# Altium schematic sheet misc.SchDoc(misc)
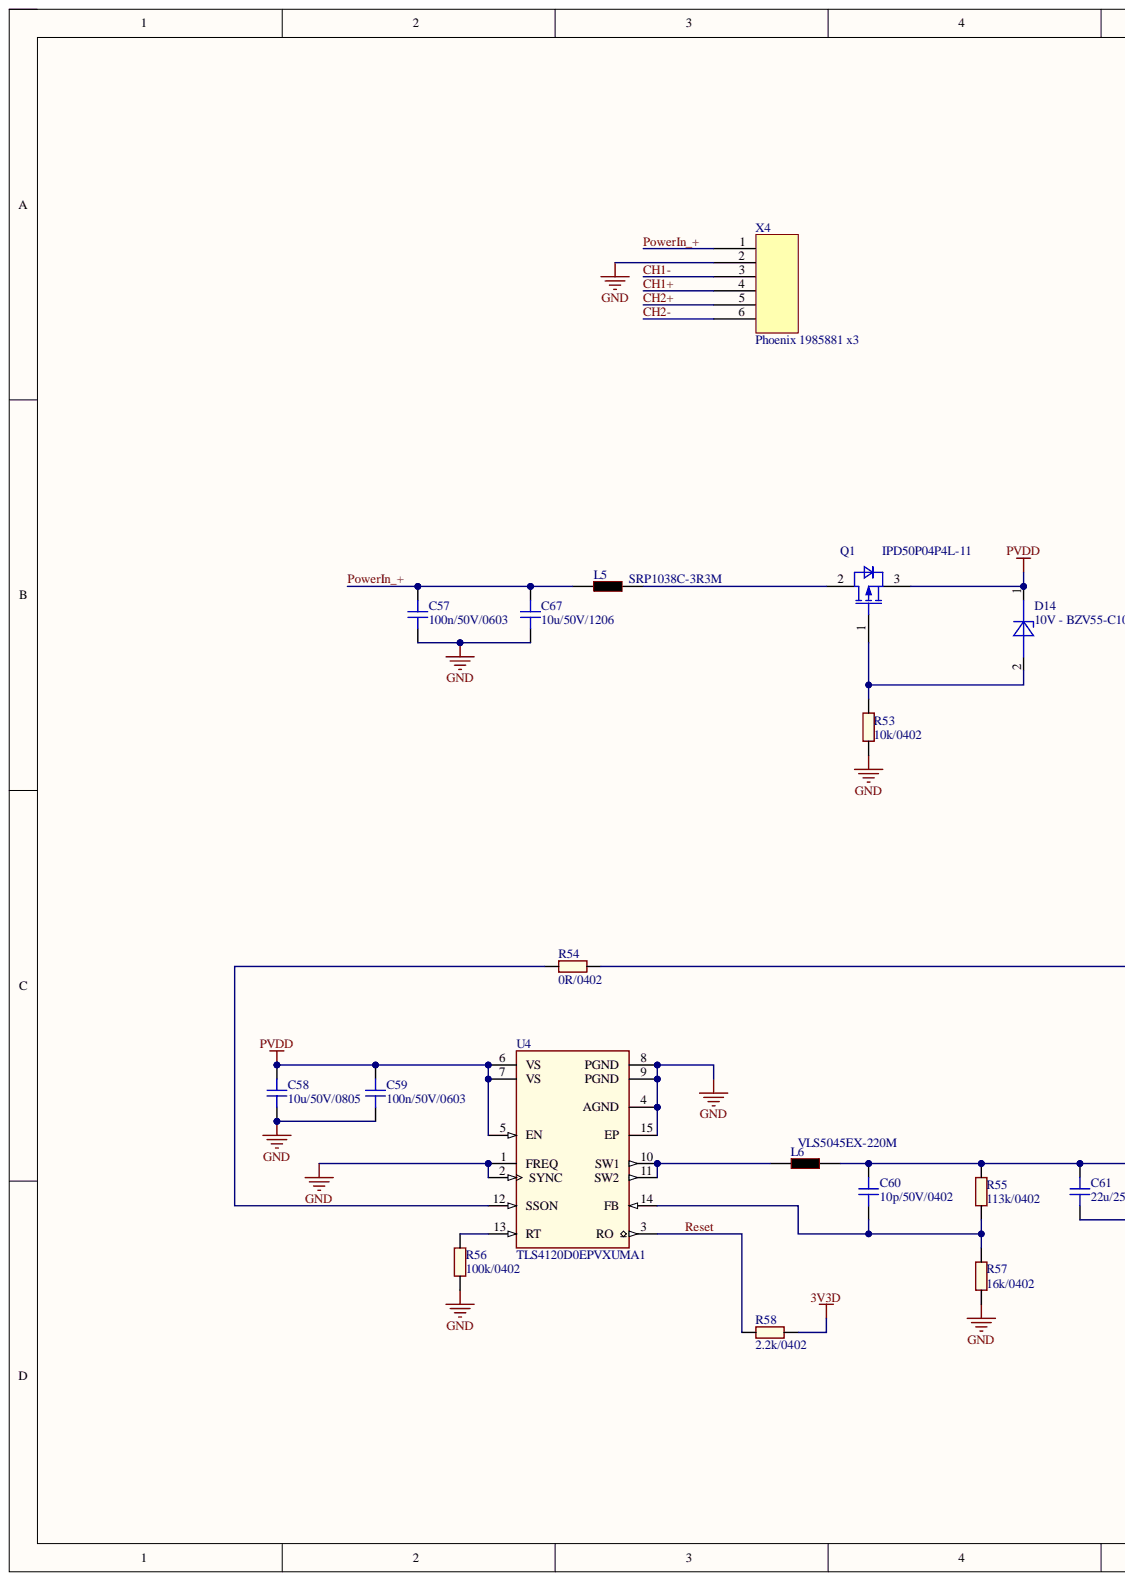
[diagram p0: misc.SchDoc, left]
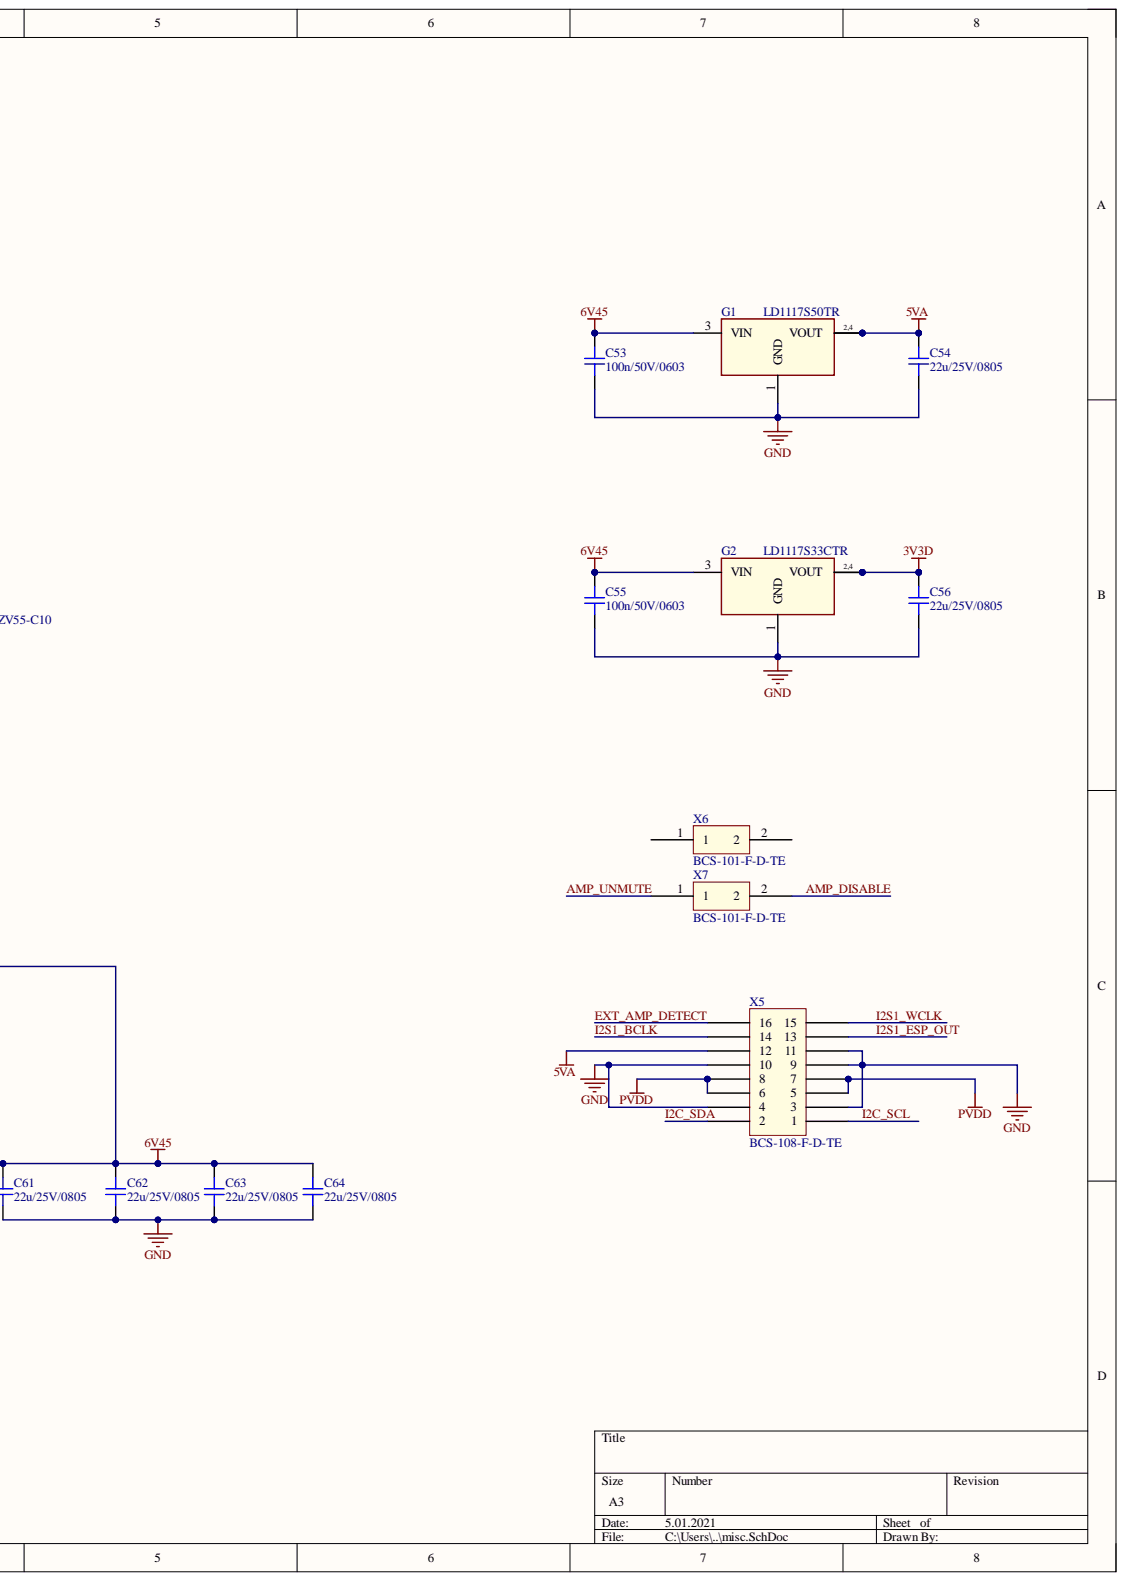
[diagram p1: misc.SchDoc, right]

## Parts
C53  100n/50V/0603  [CAPC1608X87N]
C54  22u/25V/0805  [CAPC2013X140N]
C55  100n/50V/0603  [CAPC1608X87N]
C56  22u/25V/0805  [CAPC2013X140N]
C57  100n/50V/0603  [CAPC1608X87N]
C58  10u/50V/0805  [CAPC2013X140N]
C59  100n/50V/0603  [CAPC1608X87N]
C60  10p/50V/0402  [CAPC1005X55N-0]
C61  22u/25V/0805  [CAPC2013X140N]
C62  22u/25V/0805  [CAPC2013X140N]
C63  22u/25V/0805  [CAPC2013X140N]
C64  22u/25V/0805  [CAPC2013X140N]
C67  10u/50V/1206  [CAPC3216X70N]
D14  10V - BZV55-C10  value 10V  [DIOMELF3515N-2]
G1  LD1117S50TR  [SOT230P700X180-4N]
G2  LD1117S33CTR  [SOT230P700X180-4N]
L5  SRP1038C-3R3M  [SRP1038C]
L6  VLS5045EX-220M  [VLS5045EX]
Q1  IPD50P04P4L-11  [TO228P998X250-3N-V]
R53  10k/0402  [RESC1005X40N]
R54  0R/0402  [RESC1005X40N]
R55  113k/0402  [RESC1005X40N]
R56  100k/0402  [RESC1005X40N]
R57  16k/0402  [RESC1005X40N]
R58  2.2k/0402  [RESC1005X40N]
U4  TLS4120D0EPVXUMA1  [SOP65P600X115-15N]
X4  Phoenix 1985881 x3  [Phoenix 1985881 x3]
X5  BCS-108-F-D-TE  [BCS-108-F-D-TE]
X6  BCS-101-F-D-TE  [BCS-101-F-D-TE]
X7  BCS-101-F-D-TE  [BCS-101-F-D-TE]

## Nets
3V3D: C56.2 G2.2(VOUT) G2.4 R58.1
5VA: C54.2 G1.2(VOUT) G1.4 X5.12
6V45: C53.1 C55.1 C60.2 C61.2 C62.2 C63.2 C64.2 G1.3(VIN) G2.3(VIN) L6.2 R54.1 R55.2
GND: C53.2 C54.1 C55.2 C56.1 C57.2 C58.1 C59.2 C61.1 C62.1 C63.1 C64.1 C67.1 G1.1(GND) G2.1(GND) R53.1 R56.1 R57.1 U4.1(FREQ) U4.2(SYNC) U4.4(AGND) U4.8(PGND) U4.9(PGND) U4.15(EP) X4.2 X5.3 X5.4 X5.9 X5.10 X5.11
PVDD: C58.2 C59.1 D14.1 Q1.3 U4.5(EN) U4.6(VS) U4.7(VS) X5.5 X5.6 X5.7 X5.8
AMP_DISABLE: X7.2
AMP_UNMUTE: X7.1
CH1+: X4.4
CH1-: X4.3
CH2+: X4.5
CH2-: X4.6
EXT_AMP_DETECT: X5.16
I2C_SCL: X5.1
I2C_SDA: X5.2
I2S1_BCLK: X5.14
I2S1_ESP_OUT: X5.13
I2S1_WCLK: X5.15
PowerIn_+: C57.1 C67.2 L5.1 X4.1
Reset: R58.2 U4.3(RO)
NetC60_1: C60.1 R55.1 R57.2 U4.14(FB)
NetD14_2: D14.2 Q1.1 R53.2
NetL5_2: L5.2 Q1.2
NetL6_1: L6.1 U4.10(SW1) U4.11(SW2)
NetR54_2: R54.2 U4.12(SSON)
NetR56_2: R56.2 U4.13(RT)

Not connected: X6 1 2

## Library data of the parts
C53 C55 C57 C59 = 251321: CAP / CERA / 100nF / 10V / 5% / X7R (EIA) / -55°C to 125°C / 603(1608) / SMD / -; Manufacturer: Kemet; Manufacturer Order Number: C0603C104J8RAC; Alternate View: Yes; Automotive Qualified: No; Combined Parameters: TC:X7R (EIA);Tol.:-5%/+5%;Vdc:10V; ComponentStatus: Released; Database Table Name: TPartsAll; Legacy DeviceID: CAP00004163; Package Imperial: 603; Package Metric: 1608; PLMStatus: Not Recommended For New Designs; QuickPick: 100nF / 10V / 5% / X7R (EIA) / 0603; Style: Single; Symbol Type: Capacitor; Single; Standard; 2 Pins; Type: Standard; Version Number: V01; Article Number: 251321; Component Kind: Standard; ComponentLink1Description: Vendor WebSite; ComponentLink1URL: http://www.kemet.com; ComponentLink2Description: Datasheet; ComponentLink2URL: http://webnetprod.muc.infineon.com/PCBPortal_Prod/WorkFlows/AltiumComponent/StandardDocumentation?Versionid=251322; Legacy PartNumber: CAP00004163; Library Name: PCBLibraryData.SVNDbLib; Multiple Parts: No; Package Description: 0603; PackageReference: 0603; Part Type: Capacitor; ROHS Compliant: Y; Second Source allowed: Yes; Supplier 1: Farnell; Supplier 2: DigiKey; Supplier 3: Mouser; Supplier Part Number 2: C0603C104J8RACTU-ND; Technology: Ceramic; Temperature max: 125; Temperature min: -55; Temperature Range: Military; Tolerance Neg: 5%; Tolerance Pos: 5%; Value: 100nF; Voltage DC (max): 10V
C54 C56 C58 C61-C64 = 304206: CAP / CERA / 330nF / 25V / 5% / X8R (EIA) / -55°C to 150°C / 0805(2012) / SMD / -; Manufacturer: MuRata; Manufacturer Order Number: GCM21BR91E334JA37; Multiple Parts: No; Alternate View: Yes; Automotive Qualified: AEC Q200; Combined Parameters: TC:X8R (EIA);Tol.:-5%/+5%;Vdc:25V; ComponentStatus: Released; Database Table Name: TPartsAll; Legacy DeviceID: CAP01009910; Package Imperial: 0805; Package Metric: 2012; PLMStatus: Active; QuickPick: 330nF / 25V / 5% / X8R (EIA) / CAPC2013X140N; Style: Single; Symbol Type: Capacitor; Single; Standard; 2 Pins; Type: Standard; Version Number: V01; Application: Standard; Article Number: 304206; Component Kind: Standard; ComponentLink1Description: Vendor WebSite; ComponentLink1URL: http://www.murata.com/; ComponentLink2Description: Datasheet; ComponentLink2URL: http://webnetprod.muc.infineon.com/PCBPortal_Prod/WorkFlows/AltiumComponent/StandardDocumentation?Versionid=304207; Legacy PartNumber: CAP01009910; Library Name: PCBLibraryData.SVNDbLib; Package Description: Capacitor,non-polarized,Chip;2.00mm L X 1.25mm W X 1.40mm H; PackageReference: CAPC2013X140N; Part Type: Capacitor; ROHS Compliant: Y; Second Source allowed: Yes; Supplier 1: Farnell; Supplier 2: DigiKey; Supplier 3: Mouser; Technology: Ceramic; Temperature max: 150; Temperature min: -55; Temperature Range: Military; Tolerance Neg: 5%; Tolerance Pos: 5%; Value: 330nF; Voltage DC (max): 25V
C60 = 263539: CAP / CERA / 10nF / 6.3V / 10% / X5R (EIA) / -55°C to 85°C / 0402(1005) / SMD / -; Manufacturer: MuRata; Manufacturer Order Number: GRM155R60J103KA01; Alternate View: Yes; Automotive Qualified: No; Combined Parameters: TC:X5R (EIA);Tol.:-10%/+10%;Vdc:6.3V; ComponentStatus: Released; Database Table Name: TPartsAll; Legacy DeviceID: CAP01001500; Package Imperial: 0402; Package Metric: 1005; PLMStatus: Not Recommended For New Designs; QuickPick: 10nF / 6.3V / 10% / X5R (EIA) / CAPC1005X55N-0; Style: Single; Symbol Type: Capacitor; Single; Standard; 2 Pins; Type: Standard; Version Number: V01; Application: Standard; Article Number: 263539; Component Kind: Standard; ComponentLink1Description: Vendor WebSite; ComponentLink1URL: http://www.murata.com/; ComponentLink2Description: Datasheet; ComponentLink2URL: http://webnetprod.muc.infineon.com/PCBPortal_Prod/WorkFlows/AltiumComponent/StandardDocumentation?Versionid=263540; Legacy PartNumber: CAP01001500; Library Name: PCBLibraryData.SVNDbLib; Multiple Parts: No; Package Description: Capacitor,non-polarized,Chip;1.00mm L X 0.50mm W X 0.55mm H; PackageReference: CAPC1005X55N-0; Part Type: Capacitor; ROHS Compliant: Y; Second Source allowed: Yes; Supplier 1: Farnell; Supplier 2: DigiKey; Supplier 3: Mouser; Technology: Ceramic; Temperature max: 85; Temperature min: -55; Temperature Range: Military; Tolerance Neg: 10%; Tolerance Pos: 10%; Value: 10nF; Voltage DC (max): 6.3V
C67 = 279843: CAP / CERA / 2.2uF / 16V / 10% / X5R (EIA) / -55°C to 85°C / 1206(3216) / SMD / -; Manufacturer: MuRata; Manufacturer Order Number: GRM316R61C225KA88; Alternate View: Yes; Automotive Qualified: No; Combined Parameters: TC:X5R (EIA);Tol.:-10%/+10%;Vdc:16V; ComponentStatus: Released; Database Table Name: TPartsAll; Legacy DeviceID: CAP01005013; Package Imperial: 1206; Package Metric: 3216; PLMStatus: Not Recommended For New Designs; QuickPick: 2.2uF / 16V / 10% / X5R (EIA) / CAPC3216X70N; Style: Single; Symbol Type: Capacitor; Single; Standard; 2 Pins; Type: Standard; Version Number: V01; Application: Standard; Article Number: 279843; Component Kind: Standard; ComponentLink1Description: Vendor WebSite; ComponentLink1URL: http://www.murata.com/; ComponentLink2Description: Datasheet; ComponentLink2URL: http://webnetprod.muc.infineon.com/PCBPortal_Prod/WorkFlows/AltiumComponent/StandardDocumentation?Versionid=279844; Legacy PartNumber: CAP01005013; Library Name: PCBLibraryData.SVNDbLib; Multiple Parts: No; Package Description: Capacitor,non-polarized,Chip;3.20mm L X 1.60mm W X 0.70mm H; PackageReference: CAPC3216X70N; Part Type: Capacitor; ROHS Compliant: Y; Second Source allowed: Yes; Supplier 1: Farnell; Supplier 2: DigiKey; Supplier 3: Mouser; Technology: Ceramic; Temperature max: 85; Temperature min: -55; Temperature Range: Military; Tolerance Neg: 10%; Tolerance Pos: 10%; Value: 2.2uF; Voltage DC (max): 16V
D14 = 391448: Voltage regulator diode, 10V; Manufacturer: Nexperia; Manufacturer Order Number: BZV55-C10,135; Automotive Qualified: No; Combined Parameters: Vf:900V;If:10mA;Ur:7V;Ir:200nA;Pmax:500mW; ComponentStatus: Released; Database Table Name: TPartsAll; Legacy DeviceID: DIO00200016; PLMStatus: Active; QuickPick: Zener Diode / SOD80C; Second Source allowed: Yes; Style: Single; Symbol Type: Standard Zener Diode, 1 Cathode, 2 Anode; Type: Zener Diode; Version Number: V01; Voltage DC (max): na; Application: Standard; Article Number: 391448; Component Kind: Standard; ComponentLink1Description: Vendor WebSite; ComponentLink1URL: http://www.nexperia.com; ComponentLink2Description: Datasheet; ComponentLink2URL: http://webnetprod.muc.infineon.com/PCBPortal_Prod/WorkFlows/AltiumComponent/StandardDocumentation?Versionid=391449; Legacy PartNumber: DIO00200016; Library Name: PCBLibraryData.SVNDbLib; Package Description: Diode,MELF;3.50mm L X 1.525mm Dia; PackageReference: SOD80C; Part Type: Diode; ROHS Compliant: Y; Supplier 1: Farnell; Supplier 2: DigiKey; Supplier 3: Mouser; Supplier Part Number 2: 1727-2646-2-ND; Supplier Part Number 3: 771-BZV55-C10135; Temperature max: 200; Temperature min: -65; Temperature Range: Military
G1 G2 = 408880: Low Drop Voltage Regulator, Output Voltage 5.0V; Legacy PartNumber: IC02600551; Manufacturer: STMicroelectronics; Manufacturer Order Number: LD1117AS33TR; Automotive Qualified: No; Combined Parameters: Linear Not applicable -;Vo:5V to 5V; ComponentStatus: Released; Database Table Name: TPartsAll; Legacy DeviceID: ST_LD1117AS33TR; PLMStatus: Active; QuickPick: Linear / SOT-223; Second Source allowed: Yes; Style: Not applicable; Value: LD1117AS33TR; Version Number: V01; Voltage DC (max): na; Article Number: 408880; Component Kind: Standard; ComponentLink1Description: Vendor WebSite; ComponentLink1URL: http://www.st.com; ComponentLink2Description: Datasheet; ComponentLink2URL: http://webnetprod.muc.infineon.com/PCBPortal_Prod/WorkFlows/AltiumComponent/StandardDocumentation?Versionid=408881; Library Name: PCBLibraryData.SVNDbLib; Package Description: SOT,2.30mm pitch;4 pin,3.50mm W X 6.50mm L X 1.80mm H body; PackageReference: SOT-223; Part Type: Power Supply; ROHS Compliant: Y; Supplier 1: Farnell; Supplier 2: DigiKey; Supplier 3: Mouser; Supplier Part Number 1: 2253486; Supplier Part Number 2: 497-6447-2-ND; Supplier Part Number 3: 511-LD1117S50; Symbol Type: Low Drop Voltage Regulator; Temperature max: 125; Temperature min: 0; Temperature Range: Military; Type: Linear
L5 = SRP1038: Component Kind: Standard; Library Name: MarkusLib.IntLib
L6 = VLS5045EX: Component Kind: Standard; Library Name: MarkusLib.IntLib
Q1 = 513729: OptiMOS Power-Transistor; Manufacturer: Infineon Technologies; Automotive Qualified: Yes; Combined Parameters: N-Channel Enhancement;Uds:-40V;Id:50A;Rds:-;P:-; ComponentStatus: Released; Database Table Name: TPartsAll; Legacy DeviceID: IFX_IPD50P04P4L-11; PLMStatus: Active; QuickPick: N-Channel Enhancement / 50A / -40V / PG-TO252-3-313; Style: Single; Symbol Type: Insulated-Gate Field-Effect Transistor (IGFET), P-Channel, Enhancement,  Body Diode, Pin 1 Gate, 2 Drain, 3 Source, 3 Pins; Type: N-Channel Enhancement; Version Number: V01; Voltage DC (max): na; Application: Protection; Article Number: 513729; Component Kind: Standard; ComponentLink1Description: Vendor WebSite; ComponentLink1URL: https://www.infineon.com/; ComponentLink2Description: Datasheet; ComponentLink2URL: http://webnetprod.muc.infineon.com/PCBPortal_Prod/WorkFlows/AltiumComponent/StandardDocumentation?Versionid=513730; Library Name: PCBLibraryData.SVNDbLib; Manufacturer Order Number: IPD50P04P4L-11; Package Description: TO,2.28mm pitch;2 pin,9.98mm L X 6.12mm W X 2.50mm H body; PackageReference: PG-TO252-3-313; Part Type: Transistor FET; ROHS Compliant: Y; Second Source allowed: Yes; Supplier 1: Farnell; Supplier 2: DigiKey; Supplier 3: Mouser; Supplier Part Number 2: IPD50P04P4L11ATMA1CT-ND; Temperature max: 175; Temperature min: -55; Temperature Range: Military; Value: IPD50P04P4L-11
R53-R58 = 342167: RES / STD / 10k / 63mW / 1% / 100ppm/K / -55°C to 155°C / 0402 / SMD / -; Manufacturer: Vishay; Manufacturer Order Number: CRCW040210K0FK; Multiple Parts: No; Alternate View: Yes; Automotive Qualified: AEC Q200; Combined Parameters: TCR:100ppm/K;Tol.:-1%/+1%;Vdc:50V;Idc:-;P:63mW; ComponentStatus: Released; Database Table Name: TPartsAll; Legacy DeviceID: RES01800457; PLMStatus: Active; QuickPick: 10k / 1% / 0.063 / 0402; Style: Single; Symbol Type: Resistor; Single; Standard; 2 Pins; Version Number: V01; Voltage DC (max): 50V; Article Number: 342167; Component Kind: Standard; ComponentLink1Description: Vendor WebSite; ComponentLink1URL: http://www.vishay.com; ComponentLink2Description: Datasheet; ComponentLink2URL: http://webnetprod.muc.infineon.com/PCBPortal_Prod/WorkFlows/AltiumComponent/StandardDocumentation?Versionid=342168; Legacy PartNumber: RES01800457; Library Name: PCBLibraryData.SVNDbLib; PackageReference: 0402; Part Type: Resistor; ROHS Compliant: Y; Second Source allowed: Yes; Supplier 1: Farnell; Supplier 2: DigiKey; Supplier 3: Mouser; Technology: Thick Film; Temperature max: 155; Temperature min: -55; Temperature Range: Military; Tolerance Neg: 1%; Tolerance Pos: 1%; Type: Standard; Value: 10k
U4 = 513810: OPTIREG Switcher 2.8 MHz Synchronous Step-Down Regulator, 2.5A, output voltage(3V-10V), Input voltage 3.7 V to 35 V; Manufacturer: Infineon Technologies; Manufacturer Order Number: TLS4125D0EPV; Alternate View: No; Automotive Qualified: AEC Q100/Q101; Combined Parameters: Switched DC/DC -;Vo:3V to 10V; ComponentStatus: Released; Database Table Name: TPartsAll; Legacy DeviceID: IFX_TLS4125D0EPV; PLMStatus: Active; QuickPick: Switched / PG-TSDSO-14-5; Second Source allowed: Yes; Style: DC/DC; Value: TLS4125D0EPV; Version Number: V01; Voltage DC (max): na; Article Number: 513810; Component Kind: Standard; ComponentLink1Description: Vendor WebSite; ComponentLink1URL: https://www.infineon.com/; ComponentLink2Description: Datasheet; ComponentLink2URL: http://webnetprod.muc.infineon.com/PCBPortal_Prod/WorkFlows/AltiumComponent/StandardDocumentation?Versionid=513811; Library Name: PCBLibraryData.SVNDbLib; Multiple Parts: No; Package Description: SOP,0.65mm pitch;14 pin,3.90mm W X 4.90mm L X 1.15mm H body (w/thermal tab); PackageReference: PG-TSDSO-14-5; Part Type: Power Supply; ROHS Compliant: Y; Supplier 1: Farnell; Supplier 2: DigiKey; Supplier 3: Mouser; Symbol Type: Synchronous Step-Down Regulator; Temperature max: 150; Temperature min: -40; Temperature Range: Automotive; Type: Switched
X4 = Phoenix 1985881 x3: Component Kind: Standard; Library Name: MarkusLib.IntLib
X5 = BCS-108-F-D-TE: Component Kind: Standard; Library Name: MarkusLib.IntLib
X6 X7 = BCS-101-F-D-TE: Component Kind: Standard; Library Name: MarkusLib.IntLib
